# Altium schematic sheet Out1.SchDoc
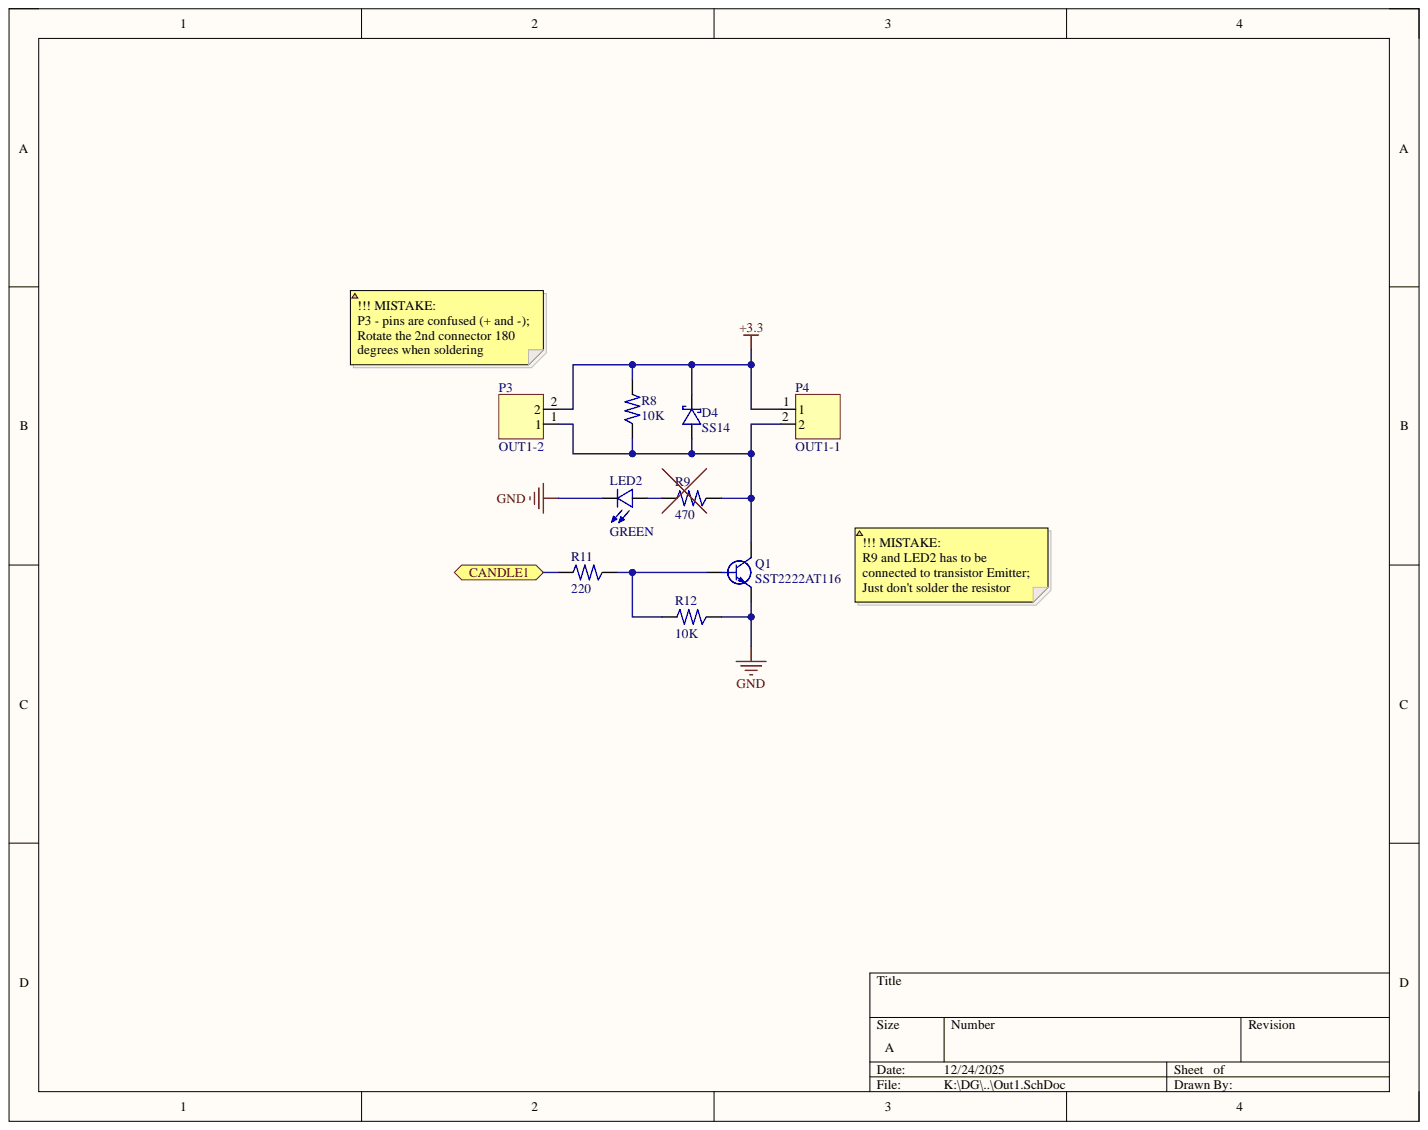
[diagram p0: Out1.SchDoc]

## Parts
D4  SS14  [SMT_DIODE_SCHTKY_VISHAI]
LED2  GREEN  [SMT_LED_0805(2012)_WURTH]
P3  OUT1-2  [THT_CON_WAFER_2P_NEL_2217S-02-WH2]
P4  OUT1-1  [THT_CON_WAFER_2P_NEL_2217S-02-WH2]
Q1  SST2222AT116  [SMT_TRANS_SST2222AT116_SOT23]
R8  10K  [SMT_RES_0805(2012)]
R9  470  [SMT_RES_0805(2012)]
R11  220  [SMT_RES_0805(2012)]
R12  10K  [SMT_RES_0805(2012)]

## Ports
CANDLE1

## Nets
+3.3: D4.2 P3.2 P4.1 R8.1
GND: LED2.1 Q1.1 R12.2
CANDLE1: R11.1 ; port CANDLE1
NetD4_1: D4.1 P3.1 P4.2 Q1.3 R8.2 R9.2
NetLED2_2: LED2.2 R9.1
NetQ1_2: Q1.2 R11.2 R12.1

## Library data of the parts
D4 = SMT_DIODE_SCHTKY_VISHAI: SMT Diode Schottky Vishay DO-214AC SS14-M3/5AT IF=1A VR=40 V FV=0.5VG; Component Kind: Standard; Library Name: SMT_DIODE_SCHTKY_VISHAI.IntLib
LED2 = SMT_LED_0805(2012)_WURTH: LED 0805(2012) 20mA 2V 150080YS75000 by Wurth Elektronik; Component Kind: Standard; Library Name: SMT_LED_0805(2012)_WURTH.IntLib
P3 P4 = THT_CON_WAFER_2P_NEL_2217S-02-WH2: Connector Wafer NELTRON 2-Pins Pitch-2.54mm 250V/3A 2217S-02-WH2; Component Kind: Standard; Library Name: THT_CON_WAFER_2P_NEL_2217S-02-WH2.SchLib
Q1 = SMT_TRANS_SST2222AT116_SOT23: Transistor NPN SST2222AT116 SOT23 700mA 40V; Component Kind: Standard; Library Name: SMT_TRANS_SST2222AT116_SOT23.IntLib
R8 R9 R11 R12 = SMT_RES_0805(2012): 0805(2012) Resistor 1/4-1/8W 150V 2A; Component Kind: Standard; Library Name: SMT_RES_0805(2012).IntLib
